# Altium schematic sheet main-power.SchDoc(main-power)
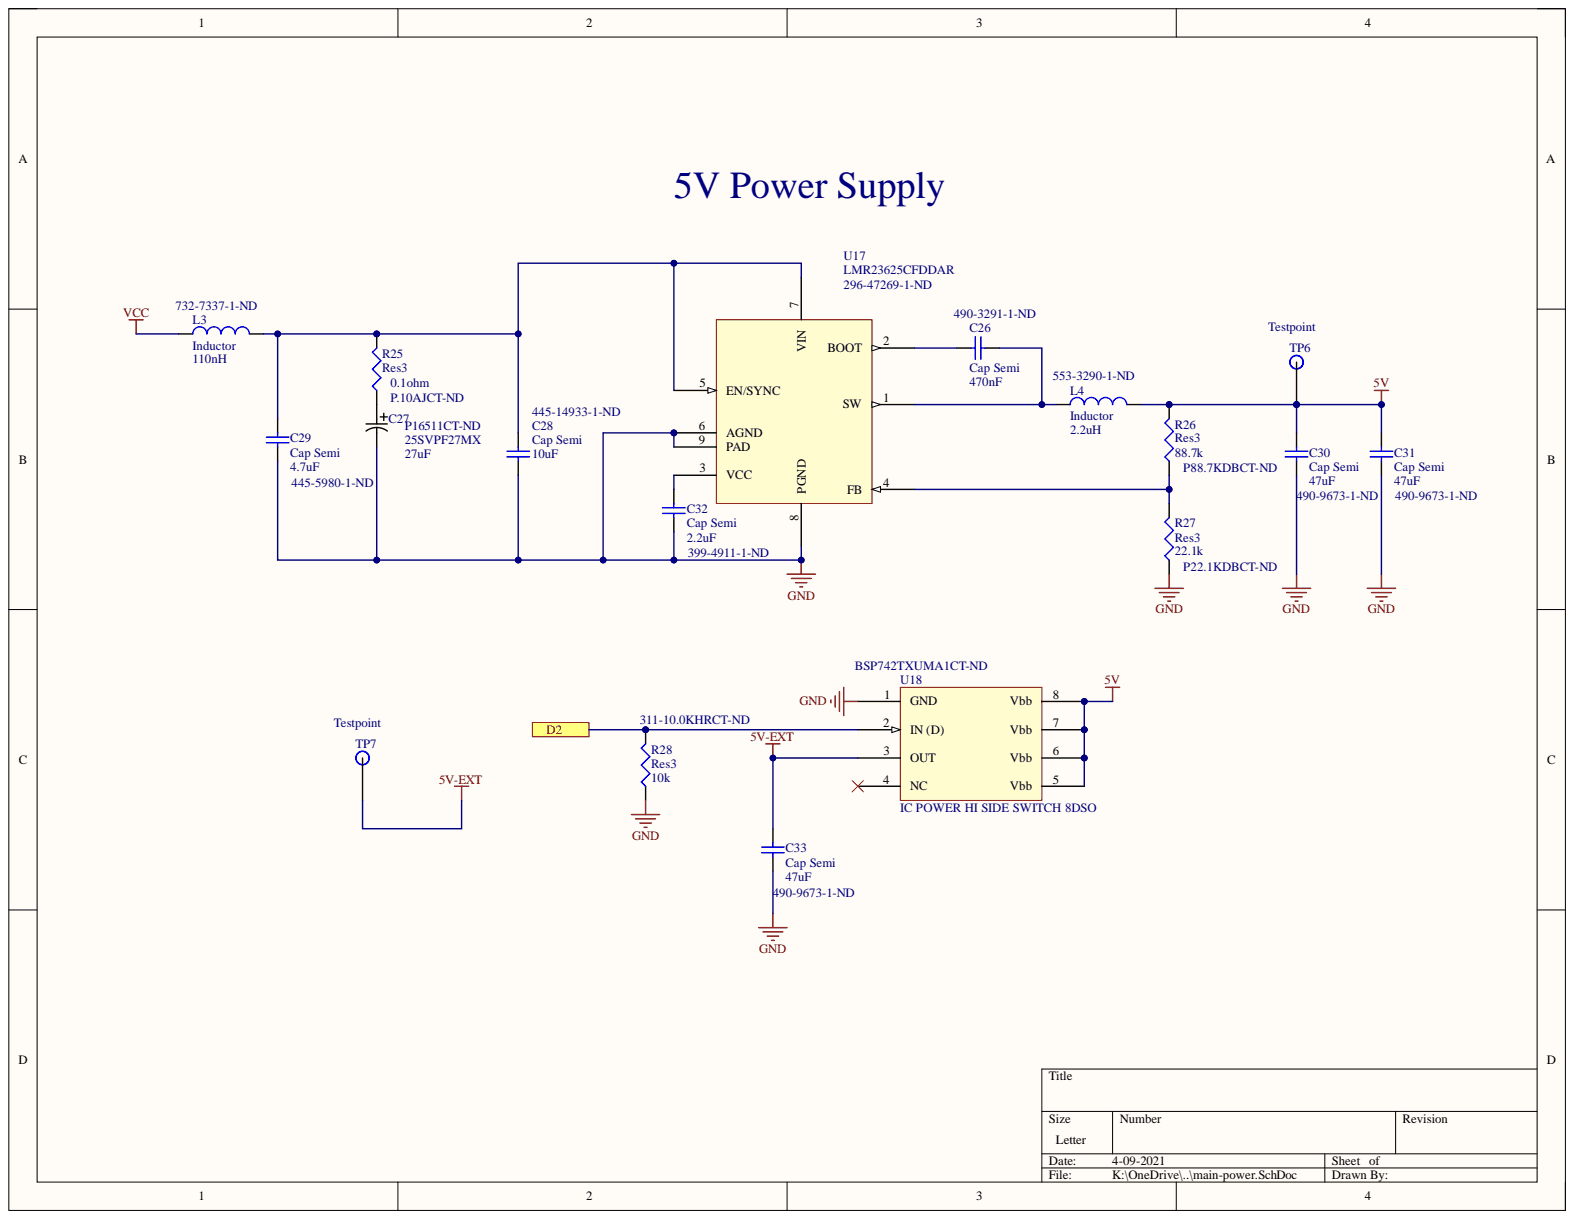
[diagram p0: main-power.SchDoc]

## Parts
C26  Cap Semi  value 470nF  Capacitance: 470nF  Supplier Part Number 1: 490-3291-1-ND  [1608[0603]]
C27  Capacitance: 27uF  Supplier Part Number 1: P16511CT-ND  [PCAP_SVP_B6]  (hidden comment: Part Number: 25SVPF27MX)
C28  Cap Semi  value 10uF  Capacitance: 10uF  Supplier Part Number 1: 445-14933-1-ND  [1210]
C29  Cap Semi  value 4.7uF  Capacitance: 4.7uF  Supplier Part Number 1: 445-5980-1-ND  [0805C]
C30  Cap Semi  value 47uF  Capacitance: 47uF  Supplier Part Number 1: 490-9673-1-ND  [C1206]
C31  Cap Semi  value 47uF  Capacitance: 47uF  Supplier Part Number 1: 490-9673-1-ND  [C1206]
C32  Cap Semi  value 2.2uF  Capacitance: 2.2uF  [1608[0603]]
C33  Cap Semi  value 47uF  Capacitance: 47uF  Supplier Part Number 1: 490-9673-1-ND  [C1206]
L3  Inductor  value 110nH  Inductance: 110nH  Supplier Part Number 1: 732-7337-1-ND  [74479899111]
L4  Inductor  value 2.2uH  Inductance: 2.2uH  Supplier Part Number 1: 553-3290-1-ND  [PA4332.222NLT]
R25  Res3  value 0.1ohm  [J1-0603]
R26  Res3  value 88.7k  [J1-0603]
R27  Res3  value 22.1k  Supplier Part Number 1: P22.1KDBCT-ND  [J1-0603]
R28  Res3  value 10k  Supplier Part Number 1: 311-10.0KHRCT-ND  [J1-0603]
TP6  Testpoint  [testpoint]  (no BOM)
TP7  Testpoint  [testpoint]  (no BOM)
U17  LMR23625CFDDAR  Supplier Part Number 1: 296-47269-1-ND  [DDA0008E_L]
U18  IC POWER HI SIDE SWITCH 8DSO  Supplier Part Number 1: BSP742TXUMA1CT-ND  [SOIC127P600-8N]

## Ports
D2

## Nets
5V: C30.2 C31.2 L4.2 R26.2 TP6.1 U18.5(Vbb) U18.6(Vbb) U18.7(Vbb) U18.8(Vbb)
5V-EXT: C33.2 TP7.1 U18.3(OUT)
GND: C27.2 C28.1 C29.1 C30.1 C31.1 C32.1 C33.1 R27.1 R28.1 U17.6(AGND) U17.8(PGND) U17.9(PAD) U18.1(GND)
VCC: L3.1
D2: R28.2 U18.2(IN_(D)) ; port D2
NetC26_1: C26.1 U17.2(BOOT)
NetC26_2: C26.2 L4.1 U17.1(SW)
NetC27_1: C27.1 R25.1
NetC28_2: C28.2 C29.2 L3.2 R25.2 U17.5(EN/SYNC) U17.7(VIN)
NetC32_2: C32.2 U17.3(VCC)
NetR26_1: R26.1 R27.2 U17.4(FB)

Not connected: U18 4(NC)

## Library data of the parts
C26 = Cap Semi: Capacitor (Semiconductor SIM Model); Manufacturer: Murata; Manufacturer Part Number: GRM188R71E474KA12D; Dielectric: X7R; Material: Ceramic; Tolerance: 10%; Voltage: 50V; Voltage Rating: 25V; Case Code (Imperial): 0603; Case Code (Metric): 1608; Case/Package: 0603; Component Kind: Standard; ComponentLink1Description: https://datasheet.ciiva.com/16293/grm188r71e474ka12d-16293088.pdf?src-supplier=Future+Electronics; ComponentLink1URL: https://datasheet.ciiva.com/16293/grm188r71e474ka12d-16293088.pdf?src-supplier=Future+Electronics; ComponentLink2Description: https://datasheet.ciiva.com/7937/1747458-7937650.pdf?src-supplier=Element14; ComponentLink2URL: https://datasheet.ciiva.com/7937/1747458-7937650.pdf?src-supplier=Element14; ComponentLink3Description: https://datasheet.ciiva.com/134/grm188r71e474ka12-01-134310.pdf?src-supplier=Digi-Key; ComponentLink3URL: https://datasheet.ciiva.com/134/grm188r71e474ka12-01-134310.pdf?src-supplier=Digi-Key; ComponentLink4Description: https://datasheet.ciiva.com/34302/1857559-34302862.pdf?src-supplier=Jameco; ComponentLink4URL: https://datasheet.ciiva.com/34302/1857559-34302862.pdf?src-supplier=Jameco; ComponentLink5Description: https://datasheet.ciiva.com/29199/454518-da-01-en-vs-kondensator-0603-29199596.pdf?src-supplier=Conrad; ComponentLink5URL: https://datasheet.ciiva.com/29199/454518-da-01-en-vs-kondensator-0603-29199596.pdf?src-supplier=Conrad; ComponentLink6Description: https://datasheet.ciiva.com/134/grm188r71e474ka12-01-134308.pdf?src-supplier=Digi-Key; ComponentLink6URL: https://datasheet.ciiva.com/134/grm188r71e474ka12-01-134308.pdf?src-supplier=Digi-Key; ComponentLink7Description: https://datasheet.ciiva.com/134/grm188r71e474ka12-01-134309.pdf?src-supplier=Digi-Key; ComponentLink7URL: https://datasheet.ciiva.com/134/grm188r71e474ka12-01-134309.pdf?src-supplier=Digi-Key; ComponentLink8Description: https://datasheet.ciiva.com/22703/1747458-22703340.pdf?src-supplier=Element14; ComponentLink8URL: https://datasheet.ciiva.com/22703/1747458-22703340.pdf?src-supplier=Element14; Depth: 0.8mm; Height: 0.8mm; LatestRevisionDate: 29-May-2009; LatestRevisionNote: IPC-7351 Footprint Added.; Lead Free: Lead Free; Length: 1.6mm; Library Name: Miscellaneous Devices.IntLib; Max Operating Temperature: 125°C; Min Operating Temperature: -55°C; Mount: Surface Mount; Number of Pins: 2; Package Quantity: 4000; PackageDescription: Chip Capacitor; PackageReference: C1206; Packaging: Tape and Reel; Pricing 1: 1 = 0.25000, 10 = 0.16800, 100 = 0.08520, 500 = 0.05832, 1000 = 0.05159 (USD); Published: 8-Jun-2000; REACH SVHC: No SVHC; RoHS: Yes; Signal Integrity: Cap Semi; Simulation: CAP; Stock 1: 187331; Supplier 1: Digi-Key; Termination: SMD/SMT; Thickness: 0.035inch; Voltage Rating (DC): 25V; Width: 0.031inch; Publisher: Altium Limited
C27 = 25SVPF27MX: No Description Available; Manufacturer: Panasonic; Built_By: EMA_UL_Team; Dissipation Factor: 12%; ESR (Equivalent Series Resistance): 40mR; Leakage Current: 135uA; Life (Hours): 5000Hour; Polarity: Polar; Ripple Current: 2.45A; Tolerance: 20%; Type: DEV; Voltage: 25V; Case/Package: Radial; Component Kind: Standard; ComponentLink1Description: https://datasheet.ciiva.com/24094/svpf-os-262415-24094277.pdf?src-supplier=Mouser; ComponentLink1URL: https://datasheet.ciiva.com/24094/svpf-os-262415-24094277.pdf?src-supplier=Mouser; ComponentLink2Description: https://datasheet.ciiva.com/49031/70324301-49031162.pdf?src-supplier=Allied+Electronics; ComponentLink2URL: https://datasheet.ciiva.com/49031/70324301-49031162.pdf?src-supplier=Allied+Electronics; ComponentLink3Description: https://datasheet.ciiva.com/25746/1734360-25746540.pdf?src-supplier=Farnell; ComponentLink3URL: https://datasheet.ciiva.com/25746/1734360-25746540.pdf?src-supplier=Farnell; ComponentLink4Description: https://datasheet.ciiva.com/22746/1734360-22746216.pdf?src-supplier=Element14; ComponentLink4URL: https://datasheet.ciiva.com/22746/1734360-22746216.pdf?src-supplier=Element14; Diameter: 5mm; Height: 5.9mm; Height - Seated (Max): 0.236inch; Length: 5.9mm; Library Name: Panasonic.IntLib; Manufacturer Part Number: 25SVPF27MX; Manufacturer_Part_Number: 25SVPF27MX; Max Operating Temperature: 105°C; Min Operating Temperature: -55°C; Mount: Surface Mount; Number of Pins: 6; Package Quantity: 1500; Packaging: Tape and Reel; Pricing 1: 1 = 1.02000, 10 = 0.80900, 100 = 0.60650, 500 = 0.45826 (USD); REACH SVHC: No SVHC; RefDes: RefDes; Ripple Current (AC): 2.45A; RoHS: Yes; Stock 1: 3478; Supplier 1: Digi-Key; Termination: SMD/SMT; Value: Value; Vendor: Panasonic; Voltage Rating: 25V; Voltage Rating (DC): 25V; Copyright: Copyright (C) 2018 Accelerated Designs. All rights reserved
C28 = Cap Semi: Capacitor (Semiconductor SIM Model); Manufacturer: TDK; Manufacturer Part Number: C3225X7R1H106M250AC; Dielectric: X7R; Dielectric Material: Ceramic; Tolerance: 20%; Voltage Rating: 50V; Case Code (Imperial): 1210; Case Code (Metric): 3225; Case/Package: 1210; Component Kind: Standard; ComponentLink1Description: http://www.farnell.com/datasheets/2291921.pdf?src-supplier=Farnell; ComponentLink1URL: http://www.farnell.com/datasheets/2291921.pdf?src-supplier=Farnell; ComponentLink2Description: http://www.mouser.com/ds/2/400/tdk_mlcc_commercial_general_en-542463.pdf?src-supplier=Mouser; ComponentLink2URL: http://www.mouser.com/ds/2/400/tdk_mlcc_commercial_general_en-542463.pdf?src-supplier=Mouser; ComponentLink3Description: https://product.tdk.com/info/en/documents/chara_sheet/C3225X7R1H106M250AC.pdf?src-supplier=Digi-Key; ComponentLink3URL: https://product.tdk.com/info/en/documents/chara_sheet/C3225X7R1H106M250AC.pdf?src-supplier=Digi-Key; ComponentLink4Description: http://product.tdk.com/capacitor/mlcc/en/documents/mlcc_commercial_general_en.pdf?src-supplier=Avnet; ComponentLink4URL: http://product.tdk.com/capacitor/mlcc/en/documents/mlcc_commercial_general_en.pdf?src-supplier=Avnet; ComponentLink5Description: http://www.farnell.com/datasheets/1913358.pdf?src-supplier=Element14; ComponentLink5URL: http://www.farnell.com/datasheets/1913358.pdf?src-supplier=Element14; ComponentLink6Description: http://www.farnell.com/datasheets/2291921.pdf?src-supplier=Newark; ComponentLink6URL: http://www.farnell.com/datasheets/2291921.pdf?src-supplier=Newark; Height: 2.5mm; LatestRevisionDate: 29-May-2009; LatestRevisionNote: IPC-7351 Footprint Added.; Lead Free: Lead Free; Length: 0.126inch; Library Name: Miscellaneous Devices.IntLib; Max Operating Temperature: 125°C; Min Operating Temperature: -55°C; Mount: Surface Mount; Package Quantity: 1000; PackageDescription: Chip Capacitor; PackageReference: C1206; Packaging: Tape and Reel; Pricing 1: 1 = 1.57000, 10 = 1.24200, 100 = 0.93150, 500 = 0.70380 (USD); Published: 8-Jun-2000; REACH SVHC: No SVHC; RoHS: Yes; Signal Integrity: Cap Semi; Simulation: CAP; Stock 1: 227657; Supplier 1: Digi-Key; Termination: SMD/SMT; Thickness: 0.11inch; Voltage Rating (DC): 50V; Weight: 0.000564oz; Width: 0.098inch; Publisher: Altium Limited
C29 = Cap Semi: Capacitor (Semiconductor SIM Model); Manufacturer: TDK; Manufacturer Part Number: C2012X5R1H475K125AB; Dielectric: X5R; Dielectric Material: Ceramic; Tolerance: 10%; Voltage: 50V; Case Code (Imperial): 0805; Case Code (Metric): 2012; Case/Package: 0805; Component Kind: Standard; ComponentLink1Description: https://datasheet.ciiva.com/24216/c2012x5r1h475k125ab-265006-24216434.pdf?src-supplier=Mouser; ComponentLink1URL: https://datasheet.ciiva.com/24216/c2012x5r1h475k125ab-265006-24216434.pdf?src-supplier=Mouser; ComponentLink2Description: https://datasheet.ciiva.com/28673/mlcc-commercial-general-en-28673541.pdf?src-supplier=Element14; ComponentLink2URL: https://datasheet.ciiva.com/28673/mlcc-commercial-general-en-28673541.pdf?src-supplier=Element14; ComponentLink3Description: https://datasheet.ciiva.com/17487/e412-c-17487414.pdf?src-supplier=Avnet+Europe; ComponentLink3URL: https://datasheet.ciiva.com/17487/e412-c-17487414.pdf?src-supplier=Avnet+Europe; ComponentLink4Description: https://datasheet.ciiva.com/22725/1913358-22725564.pdf?src-supplier=Element14; ComponentLink4URL: https://datasheet.ciiva.com/22725/1913358-22725564.pdf?src-supplier=Element14; ComponentLink5Description: https://datasheet.ciiva.com/6276/tdkmlcccaprange-6276526.pdf?src-supplier=Digi-Key; ComponentLink5URL: https://datasheet.ciiva.com/6276/tdkmlcccaprange-6276526.pdf?src-supplier=Digi-Key; ComponentLink6Description: https://datasheet.ciiva.com/48671/e412-c-48671374.pdf?src-supplier=Avnet; ComponentLink6URL: https://datasheet.ciiva.com/48671/e412-c-48671374.pdf?src-supplier=Avnet; Composition: Ceramic; Depth: 1.25mm; Height: 1.25mm; LatestRevisionDate: 29-May-2009; LatestRevisionNote: IPC-7351 Footprint Added.; Lead Free: Lead Free; Length: 2mm; Library Name: Miscellaneous Devices.IntLib; Max Operating Temperature: 85°C; Min Operating Temperature: -55°C; Mount: Surface Mount; Package Quantity: 1; PackageDescription: Chip Capacitor; PackageReference: C1206; Packaging: Tape and Reel; Pricing 1: 1 = 0.80000, 10 = 0.56600, 100 = 0.37260, 500 = 0.27600, 1000 = 0.23460 (USD); Published: 8-Jun-2000; Radiation Hardening: No; REACH SVHC: No SVHC; RoHS: Yes; Signal Integrity: Cap Semi; Simulation: CAP; Stock 1: 412452; Supplier 1: Digi-Key; Termination: SMD/SMT; Thickness: 0.057inch; Voltage Rating: 50V; Voltage Rating (DC): 50V; Weight: 0.000194oz; Width: 0.049inch; Publisher: Altium Limited
C30 C31 C33 = Cap Semi: Capacitor (Semiconductor SIM Model); Manufacturer: Murata; Manufacturer Part Number: GRM31CR61A476KE15L; Dielectric: X5R; Material: Ceramic; Tolerance: 10%; Voltage: 10V; Case Code (Imperial): 1206; Case Code (Metric): 3216; Case/Package: 1206; Component Kind: Standard; ComponentLink1Description: https://datasheet.ciiva.com/29536/grm31cr61a476ke15-01-29536840.pdf?src-supplier=Digi-Key; ComponentLink1URL: https://datasheet.ciiva.com/29536/grm31cr61a476ke15-01-29536840.pdf?src-supplier=Digi-Key; ComponentLink2Description: https://datasheet.ciiva.com/13337/product-13337807.pdf?src-supplier=Mouser; ComponentLink2URL: https://datasheet.ciiva.com/13337/product-13337807.pdf?src-supplier=Mouser; ComponentLink3Description: https://datasheet.ciiva.com/46445/1769893-46445005.pdf?src-supplier=Element14; ComponentLink3URL: https://datasheet.ciiva.com/46445/1769893-46445005.pdf?src-supplier=Element14; ComponentLink4Description: https://datasheet.ciiva.com/41860/partnumbering-e-01-41860758.pdf?src-supplier=Digi-Key; ComponentLink4URL: https://datasheet.ciiva.com/41860/partnumbering-e-01-41860758.pdf?src-supplier=Digi-Key; ComponentLink5Description: https://datasheet.ciiva.com/46445/1769893-46445004.pdf?src-supplier=Element14; ComponentLink5URL: https://datasheet.ciiva.com/46445/1769893-46445004.pdf?src-supplier=Element14; Depth: 1.6mm; Height: 1.6mm; LatestRevisionDate: 29-May-2009; LatestRevisionNote: IPC-7351 Footprint Added.; Lead Free: Lead Free; Length: 3.2mm; Library Name: Miscellaneous Devices.IntLib; Max Operating Temperature: 85°C; Min Operating Temperature: -55°C; Mount: Surface Mount; Package Quantity: 2000; PackageDescription: Chip Capacitor; PackageReference: C1206; Packaging: Tape and Reel; Pricing 1: 1 = 0.84000, 10 = 0.63700, 100 = 0.45540, 500 = 0.35254, 1000 = 0.30357 (USD); Published: 8-Jun-2000; REACH SVHC: No SVHC; RoHS: Yes; Signal Integrity: Cap Semi; Simulation: CAP; Stock 1: 199340; Supplier 1: Digi-Key; Termination: SMD/SMT; Thickness: 0.071inch; Voltage Rating: 10V; Voltage Rating (DC): 10V; Width: 1.6mm; Publisher: Altium Limited
C32 = Cap Semi: Capacitor (Semiconductor SIM Model); Manufacturer: KEMET; Manufacturer Part Number: C0603C225K8PACTU; Dielectric: X5R; Dielectric Material: Ceramic; Tolerance: 10%; Voltage: 10V; Case/Package: 0603; Component Kind: Standard; ComponentLink1Description: https://datasheet.ciiva.com/11786/0900766b8116eb59-11786922.pdf?src-supplier=RSComponents; ComponentLink1URL: https://datasheet.ciiva.com/11786/0900766b8116eb59-11786922.pdf?src-supplier=RSComponents; ComponentLink2Description: https://datasheet.ciiva.com/18192/getdatasheetpartid-104076-18192933.pdf?src-supplier=Verical; ComponentLink2URL: https://datasheet.ciiva.com/18192/getdatasheetpartid-104076-18192933.pdf?src-supplier=Verical; ComponentLink3Description: https://datasheet.ciiva.com/7824/40889-7824025.pdf?src-supplier=Element14; ComponentLink3URL: https://datasheet.ciiva.com/7824/40889-7824025.pdf?src-supplier=Element14; ComponentLink4Description: https://datasheet.ciiva.com/26847/getdatasheetpartid-316295-26847626.pdf?src-supplier=Verical; ComponentLink4URL: https://datasheet.ciiva.com/26847/getdatasheetpartid-316295-26847626.pdf?src-supplier=Verical; Depth: 0.8mm; Height: 0.8mm; LatestRevisionDate: 29-May-2009; LatestRevisionNote: IPC-7351 Footprint Added.; Lead Free: Lead Free; Length: 1.6mm; Library Name: Miscellaneous Devices.IntLib; Max Operating Temperature: 85°C; Min Operating Temperature: -55°C; Mount: Surface Mount; Number of Pins: 2; PackageDescription: Chip Capacitor; PackageReference: C1206; Packaging: Tape and Reel; Pricing 1: 1 = 0.24000, 10 = 0.16200, 100 = 0.08220, 500 = 0.05622, 1000 = 0.04973 (USD); Published: 8-Jun-2000; Radiation Hardening: No; RoHS: Yes; Signal Integrity: Cap Semi; Simulation: CAP; Stock 1: 110745; Supplier 1: Digi-Key; Supplier Part Number 1: 399-4911-1-ND; Termination: SMD/SMT; Voltage Rating (DC): 10V; Publisher: Altium Limited
L3 = Inductor: Manufacturer: Wurth Electronics; Code_IEC: 1005; Current Rating: 4A; DC Resistance (DCR): 7mR; Self Resonant Frequency: 300MHz; Shielding: Shielded; Test Frequency: 1MHz; Tolerance: 20%; Case Code (Imperial): 1210; Case Code (Metric): 3225; Case/Package: 1210; Code_JEITA: 0402; Component Kind: Standard; ComponentLink1Description: https://katalog.we-online.de/pbs/datasheet/74479899111.pdf?src-supplier=Digi-Key; ComponentLink1URL: https://katalog.we-online.de/pbs/datasheet/74479899111.pdf?src-supplier=Digi-Key; Description: Inductor; Height - Seated (Max): 0.047inch; LatestRevisionDate: 29-May-2009; LatestRevisionNote: IPC-7351 Footprint Added.; Length: 0.126inch; Library Name: Miscellaneous Devices.IntLib; Manufacturer Part Number: 74479899111; Max Operating Temperature: 125°C; Min Operating Temperature: -40°C; Mount: Surface Mount; PackageDescription: Chip Inductor; PackageReference: 0402-A; Packaging: Tape and Reel; Pricing 1: 1 = 1.06000, 10 = 1.04200, 50 = 0.94100, 100 = 0.90700, 500 = 0.84000, 1000 = 0.77300 (USD); Published: 8-Jun-2000; RoHS: Yes; Saturation Current: 4A; Signal Integrity: Inductor; Simulation: INDUCTOR; Stock 1: 5231; Supplier 1: Digi-Key; Width: 0.102inch; Publisher: Altium Limited
L4 = Inductor: Manufacturer: Pulse Electronics; Code_IEC: 1005; Core Material: Ferrite; Current Rating: 4.3A; DC Resistance (DCR): 48mR; Saturation Current: 6.1A; Self Resonant Frequency: 25MHz; Shielding: Shielded; Test Frequency: 1MHz; Tolerance: 20%; Case/Package: Nonstandard; Code_JEITA: 0402; Component Kind: Standard; ComponentLink1Description: http://products.pulseelex.com/files/product_files/P761.pdf?src-supplier=Digi-Key; ComponentLink1URL: http://products.pulseelex.com/files/product_files/P761.pdf?src-supplier=Digi-Key; Composition: Wirewound; Description: Inductor; Height - Seated (Max): 0.079inch; LatestRevisionDate: 29-May-2009; LatestRevisionNote: IPC-7351 Footprint Added.; Length: 0.165inch; Library Name: Miscellaneous Devices.IntLib; Manufacturer Part Number: PA4332.222NLT; Max Operating Temperature: 125°C; Min Operating Temperature: -40°C; Mount: Surface Mount; PackageDescription: Chip Inductor; PackageReference: 0402-A; Packaging: Tape and Reel; Pricing 1: 1 = 0.64000, 10 = 0.56400, 100 = 0.40250, 500 = 0.33810, 1000 = 0.27370 (USD); Published: 8-Jun-2000; RoHS: Yes; Signal Integrity: Inductor; Simulation: INDUCTOR; Stock 1: 9240; Supplier 1: Digi-Key; Width: 0.165inch; Publisher: Altium Limited
R25 = Res3: Resistor; Manufacturer: Panasonic; Manufacturer Part Number: ERJ3RSFR10V; Max Power Dissipation: 100mW; Resistance: 100mR; Temperature Coefficient: 300ppm/°C; Tolerance: 1%; Case Code (Imperial): 0603; Case Code (Metric): 1608; Case/Package: 0603; Component Kind: Standard; ComponentLink1Description: https://datasheet.ciiva.com/11818/0900766b80eefa12-11818379.pdf?src-supplier=RSComponents; ComponentLink1URL: https://datasheet.ciiva.com/11818/0900766b80eefa12-11818379.pdf?src-supplier=RSComponents; ComponentLink2Description: https://datasheet.ciiva.com/9186/aoa0000ce3-9186678.pdf?src-supplier=Avnet; ComponentLink2URL: https://datasheet.ciiva.com/9186/aoa0000ce3-9186678.pdf?src-supplier=Avnet; ComponentLink3Description: https://datasheet.ciiva.com/28577/391509-28577782.pdf?src-supplier=Element14; ComponentLink3URL: https://datasheet.ciiva.com/28577/391509-28577782.pdf?src-supplier=Element14; ComponentLink4Description: https://datasheet.ciiva.com/30245/6aoa0000ce3-30245798.pdf?src-supplier=Onlinecomponents.com; ComponentLink4URL: https://datasheet.ciiva.com/30245/6aoa0000ce3-30245798.pdf?src-supplier=Onlinecomponents.com; ComponentLink5Description: https://datasheet.ciiva.com/6137/391509-6137623.pdf?src-supplier=Farnell; ComponentLink5URL: https://datasheet.ciiva.com/6137/391509-6137623.pdf?src-supplier=Farnell; ComponentLink6Description: https://datasheet.ciiva.com/16396/aoa0000ce3-16396839.pdf?src-supplier=Future+Electronics; ComponentLink6URL: https://datasheet.ciiva.com/16396/aoa0000ce3-16396839.pdf?src-supplier=Future+Electronics; ComponentLink7Description: https://datasheet.ciiva.com/17208/aoa0000ce3-17208042.pdf?src-supplier=Avnet+Europe; ComponentLink7URL: https://datasheet.ciiva.com/17208/aoa0000ce3-17208042.pdf?src-supplier=Avnet+Europe; ComponentLink8Description: https://datasheet.ciiva.com/24027/aoa0000ce3-72189-24027996.pdf?src-supplier=Mouser; ComponentLink8URL: https://datasheet.ciiva.com/24027/aoa0000ce3-72189-24027996.pdf?src-supplier=Mouser; ComponentLink9Description: https://datasheet.ciiva.com/46449/391509-46449485.pdf?src-supplier=Element14; ComponentLink9URL: https://datasheet.ciiva.com/46449/391509-46449485.pdf?src-supplier=Element14; ComponentLink10Description: https://datasheet.ciiva.com/16771/70068852-16771902.pdf?src-supplier=Allied+Electronics; ComponentLink10URL: https://datasheet.ciiva.com/16771/70068852-16771902.pdf?src-supplier=Allied+Electronics; ComponentLink11Description: https://datasheet.ciiva.com/46449/391509-46449486.pdf?src-supplier=Element14; ComponentLink11URL: https://datasheet.ciiva.com/46449/391509-46449486.pdf?src-supplier=Element14; ComponentLink12Description: https://datasheet.ciiva.com/28667/391509-28667695.pdf?src-supplier=Element14; ComponentLink12URL: https://datasheet.ciiva.com/28667/391509-28667695.pdf?src-supplier=Element14; Composition: Thick Film; Contact Plating: Tin; Depth: 0.8mm; Height: 0.45mm; LatestRevisionDate: 29-May-2009; LatestRevisionNote: IPC-7351 Footprint Added.; Lead Free: Lead Free; Length: 1.6mm; Library Name: Miscellaneous Devices.IntLib; Max Operating Temperature: 125°C; Military Standard: Not; Min Operating Temperature: -55°C; Mount: Surface Mount; Number of Pins: 2; Number of Terminations: 2; Package Quantity: 5000; PackageDescription: Chip Resistor; PackageReference: J1-0603; Packaging: Tape and Reel; Power Rating: 100mW; Pricing 1: 1 = 0.31000, 10 = 0.26200, 25 = 0.19040, 50 = 0.13800, 100 = 0.10230, 250 = 0.07852, 500 = 0.06186, 1000 = 0.04283 (USD); Published: 8-Jun-2000; Radiation Hardening: No; REACH SVHC: No SVHC; RoHS: Yes; Signal Integrity: Res; Simulation: RESISTOR; Stock 1: 499056; Supplier 1: Digi-Key; Supplier Part Number 1: P.10AJCT-ND; Termination: SMD/SMT; Width: 0.031inch; Publisher: Altium Limited
R26 = Res3: Resistor; Manufacturer: Panasonic; Manufacturer Part Number: ERA3AEB8872V; Max Power Dissipation: 100mW; Resistance: 88.7kR; Temperature Coefficient: 25ppm/°C; Tolerance: 0.1%; Voltage Rating: 75V; Case Code (Imperial): 0603; Case Code (Metric): 1608; Case/Package: 0603; Component Kind: Standard; ComponentLink1Description: https://datasheet.ciiva.com/11810/0900766b80eb1d8c-11810560.pdf?src-supplier=RSComponents; ComponentLink1URL: https://datasheet.ciiva.com/11810/0900766b80eb1d8c-11810560.pdf?src-supplier=RSComponents; ComponentLink2Description: https://datasheet.ciiva.com/7920/485442-7920389.pdf?src-supplier=Element14; ComponentLink2URL: https://datasheet.ciiva.com/7920/485442-7920389.pdf?src-supplier=Element14; ComponentLink3Description: https://datasheet.ciiva.com/6053/485442-6053174.pdf?src-supplier=Farnell; ComponentLink3URL: https://datasheet.ciiva.com/6053/485442-6053174.pdf?src-supplier=Farnell; ComponentLink4Description: https://datasheet.ciiva.com/6053/485442-6053175.pdf?src-supplier=Farnell; ComponentLink4URL: https://datasheet.ciiva.com/6053/485442-6053175.pdf?src-supplier=Farnell; ComponentLink5Description: https://datasheet.ciiva.com/6053/485442-6053177.pdf?src-supplier=Farnell; ComponentLink5URL: https://datasheet.ciiva.com/6053/485442-6053177.pdf?src-supplier=Farnell; ComponentLink6Description: https://datasheet.ciiva.com/17208/aoa0000ce26-17208382.pdf?src-supplier=Avnet+Europe; ComponentLink6URL: https://datasheet.ciiva.com/17208/aoa0000ce26-17208382.pdf?src-supplier=Avnet+Europe; Composition: Metal Film; Contact Plating: Tin; Depth: 0.8mm; Features: Automotive AEC-Q200; Height: 0.45mm; LatestRevisionDate: 29-May-2009; LatestRevisionNote: IPC-7351 Footprint Added.; Lead Free: Lead Free; Length: 1.6mm; Library Name: Miscellaneous Devices.IntLib; Max Operating Temperature: 155°C; Military Standard: Not; Min Operating Temperature: -55°C; Mount: Surface Mount; Number of Pins: 2; Number of Terminations: 2; Package Quantity: 1; PackageDescription: Chip Resistor; PackageReference: J1-0603; Packaging: Tape and Reel; Power Rating: 100mW; Pricing 1: 1 = 0.35000, 10 = 0.30000, 100 = 0.11720, 1000 = 0.04906, 2500 = 0.04498 (USD); Published: 8-Jun-2000; Radiation Hardening: No; RoHS: Yes; Signal Integrity: Res; Simulation: RESISTOR; Stock 1: 25375; Supplier 1: Digi-Key; Supplier Part Number 1: P88.7KDBCT-ND; Voltage Rating (DC): 75V; Width: 0.8mm; Publisher: Altium Limited
R27 = Res3: Resistor; Manufacturer: Panasonic; Manufacturer Part Number: ERA-3AEB2212V; Max Power Dissipation: 100mW; Resistance: 22.1kR; Temperature Coefficient: 25ppm/°C; Tolerance: 0.1%; Voltage Rating: 150V; Voltage Rating (DC): 75V; Case Code (Imperial): 0603; Case Code (Metric): 1608; Case/Package: 0603; Component Kind: Standard; ComponentLink1Description: https://datasheet.ciiva.com/11810/0900766b80eb1d8c-11810643.pdf?src-supplier=RSComponents; ComponentLink1URL: https://datasheet.ciiva.com/11810/0900766b80eb1d8c-11810643.pdf?src-supplier=RSComponents; ComponentLink2Description: https://datasheet.ciiva.com/29183/452175-da-01-en-widerstand-0603-era-29183955.pdf?src-supplier=Conrad; ComponentLink2URL: https://datasheet.ciiva.com/29183/452175-da-01-en-widerstand-0603-era-29183955.pdf?src-supplier=Conrad; ComponentLink3Description: https://datasheet.ciiva.com/17210/aoa0000ce26-17210837.pdf?src-supplier=Avnet+Europe; ComponentLink3URL: https://datasheet.ciiva.com/17210/aoa0000ce26-17210837.pdf?src-supplier=Avnet+Europe; ComponentLink4Description: https://datasheet.ciiva.com/5998/485442-5998316.pdf?src-supplier=Farnell; ComponentLink4URL: https://datasheet.ciiva.com/5998/485442-5998316.pdf?src-supplier=Farnell; ComponentLink5Description: https://datasheet.ciiva.com/9191/aoa0000ce26-9191805.pdf?src-supplier=Avnet; ComponentLink5URL: https://datasheet.ciiva.com/9191/aoa0000ce26-9191805.pdf?src-supplier=Avnet; ComponentLink6Description: https://datasheet.ciiva.com/5998/485442-5998319.pdf?src-supplier=Farnell; ComponentLink6URL: https://datasheet.ciiva.com/5998/485442-5998319.pdf?src-supplier=Farnell; ComponentLink7Description: https://datasheet.ciiva.com/46422/485442-46422607.pdf?src-supplier=Element14; ComponentLink7URL: https://datasheet.ciiva.com/46422/485442-46422607.pdf?src-supplier=Element14; Composition: Thin Film; Contact Plating: Tin; Depth: 0.8mm; Features: Automotive AEC-Q200; Height: 0.45mm; LatestRevisionDate: 29-May-2009; LatestRevisionNote: IPC-7351 Footprint Added.; Lead Free: Lead Free; Length: 1.6mm; Library Name: Miscellaneous Devices.IntLib; Max Operating Temperature: 155°C; Military Standard: Not; Min Operating Temperature: -55°C; Mount: Surface Mount; Number of Pins: 2; Number of Terminations: 2; Package Quantity: 1; PackageDescription: Chip Resistor; PackageReference: J1-0603; Packaging: Tape and Reel; Power Rating: 100mW; Pricing 1: 1 = 0.35000, 10 = 0.30000, 100 = 0.11720, 1000 = 0.04906, 2500 = 0.04498 (USD); Published: 8-Jun-2000; Radiation Hardening: No; REACH SVHC: No SVHC; RoHS: Yes; Signal Integrity: Res; Simulation: RESISTOR; Stock 1: 138256; Supplier 1: Digi-Key; Termination: SMD/SMT; Width: 0.8mm; Publisher: Altium Limited
R28 = Res3: Resistor; Manufacturer: Yageo; Manufacturer Part Number: RC0603FR-0710KL; Power Rating: 100mW; Resistance: 10kR; Temperature Coefficient: 100ppm/°C; Tolerance: 1%; Voltage Rating: 75V; Voltage Rating (DC): 50V; Case Code (Imperial): 0603; Case Code (Metric): 1608; Case/Package: 0603; Component Kind: Standard; ComponentLink1Description: https://datasheet.ciiva.com/7660/38590-7660789.pdf?src-supplier=Element14; ComponentLink1URL: https://datasheet.ciiva.com/7660/38590-7660789.pdf?src-supplier=Element14; ComponentLink2Description: https://datasheet.ciiva.com/15189/getdatasheetpartid-409289-15189591.pdf?src-supplier=Verical; ComponentLink2URL: https://datasheet.ciiva.com/15189/getdatasheetpartid-409289-15189591.pdf?src-supplier=Verical; ComponentLink3Description: https://datasheet.ciiva.com/46440/1868787-46440891.pdf?src-supplier=Element14; ComponentLink3URL: https://datasheet.ciiva.com/46440/1868787-46440891.pdf?src-supplier=Element14; ComponentLink4Description: https://datasheet.ciiva.com/7983/443971-7983596.pdf?src-supplier=Element14; ComponentLink4URL: https://datasheet.ciiva.com/7983/443971-7983596.pdf?src-supplier=Element14; ComponentLink5Description: https://datasheet.ciiva.com/7746/1738695-7746239.pdf?src-supplier=Element14; ComponentLink5URL: https://datasheet.ciiva.com/7746/1738695-7746239.pdf?src-supplier=Element14; ComponentLink6Description: https://datasheet.ciiva.com/22747/443971-22747519.pdf?src-supplier=Element14; ComponentLink6URL: https://datasheet.ciiva.com/22747/443971-22747519.pdf?src-supplier=Element14; Composition: Thick Film; Depth: 0.8mm; Height: 0.45mm; LatestRevisionDate: 29-May-2009; LatestRevisionNote: IPC-7351 Footprint Added.; Lead Free: Lead Free; Lead Pitch: 0.3mm; Length: 1.6mm; Library Name: Miscellaneous Devices.IntLib; Max Operating Temperature: 155°C; Military Standard: Not; Min Operating Temperature: -55°C; Number of Pins: 2; PackageDescription: Chip Resistor; PackageReference: J1-0603; Packaging: Tape and Reel; Pricing 1: 1 = 0.10000, 10 = 0.02400, 100 = 0.00970, 1000 = 0.00437, 2500 = 0.00379 (USD); Published: 8-Jun-2000; REACH SVHC: No SVHC; RoHS: Yes; Signal Integrity: Res; Simulation: RESISTOR; Stock 1: 90146690; Supplier 1: Digi-Key; Termination: SMD/SMT; Weight: 7.1E-05oz; Width: 0.8mm; Publisher: Altium Limited
TP6 TP7 = SMT Testpoint: Description: SMT Testpoint; Library Name: testpoints.IntLib
U17 = LMR23625CFDDAR: Manufacturer: Texas Instruments; Quiescent Current: 75uA; Component Kind: Standard; ComponentLink1Description: http://www.ti.com/lit/ds/symlink/lmr23625.pdf?src-supplier=Digi-Key; ComponentLink1URL: http://www.ti.com/lit/ds/symlink/lmr23625.pdf?src-supplier=Digi-Key; Description: LMR23625CFDDAR; Lead Free: Lead Free; Library Name: ti.IntLib; Manufacturer Part Number: LMR23625CFDDAR; Max Operating Temperature: 125°C; Min Operating Temperature: -40°C; Pricing 1: 1 = 3.24000, 10 = 2.91200, 100 = 2.38610, 500 = 2.03124, 1000 = 1.71310 (USD); RoHS: No; Stock 1: 6768; Supplier 1: Digi-Key
U18 = BSP742TXUMA1: Manufacturer: Infineon; Max Power Dissipation: 1.5W; Max Supply Voltage: 34V; Nominal Supply Current: 1mA; Number of Channels: 1; Output Current: 800mA; Component Kind: Standard; ComponentLink1Description: http://components.arrow.com/part/dataview/52118417S8296913N2051?src-supplier=Arrow; ComponentLink1URL: http://components.arrow.com/part/dataview/52118417S8296913N2051?src-supplier=Arrow; ComponentLink2Description: http://www.farnell.com/datasheets/2333312.pdf?src-supplier=Farnell; ComponentLink2URL: http://www.farnell.com/datasheets/2333312.pdf?src-supplier=Farnell; ComponentLink3Description: http://components.arrow.com/part/dataview/0323_00171565?src-supplier=Arrow+Europe; ComponentLink3URL: http://components.arrow.com/part/dataview/0323_00171565?src-supplier=Arrow+Europe; ComponentLink4Description: http://www.mouser.com/ds/2/196/Infineon-BSP742T-DS-v01_03-EN-1111163.pdf?src-supplier=Mouser; ComponentLink4URL: http://www.mouser.com/ds/2/196/Infineon-BSP742T-DS-v01_03-EN-1111163.pdf?src-supplier=Mouser; ComponentLink5Description: http://www.infineon.com/dgdl/Infineon-BSP742T-DS-v01_03-en.pdf?fileId=db3a30431689f4420116d2efc3000bf7&ack=t&src-supplier=Avnet; ComponentLink5URL: http://www.infineon.com/dgdl/Infineon-BSP742T-DS-v01_03-en.pdf?fileId=db3a30431689f4420116d2efc3000bf7&ack=t&src-supplier=Avnet; ComponentLink6Description: https://www.infineon.com/dgdl/Infineon-BSP742T-DS-v01_03-EN.pdf?fileId=5546d4625a888733015aae0b51d34c49&src-supplier=Digi-Key; ComponentLink6URL: https://www.infineon.com/dgdl/Infineon-BSP742T-DS-v01_03-EN.pdf?fileId=5546d4625a888733015aae0b51d34c49&src-supplier=Digi-Key; ComponentLink7Description: http://www.farnell.com/datasheets/2333312.pdf?src-supplier=Newark; ComponentLink7URL: http://www.farnell.com/datasheets/2333312.pdf?src-supplier=Newark; Description: IC POWER HI SIDE SWITCH 8DSO; Halogen Free: Halogen Free; Lead Free: Lead Free; Library Name: Infineon.IntLib; Manufacturer Part Number: BSP742TXUMA1; Max Operating Temperature: 150°C; Min Operating Temperature: -40°C; Mount: Surface Mount; Number of Outputs: 1; Number of Pins: 8; Packaging: Tape and Reel; Power Dissipation: 1.5W; Pricing 1: 1 = 1.73000, 10 = 1.53200, 100 = 1.21050, 500 = 0.93878, 1000 = 0.74115 (USD); Radiation Hardening: No; RoHS: Yes; Stock 1: 10093; Supplier 1: Digi-Key
